# Altium schematic sheet tank_adapter.SchDoc
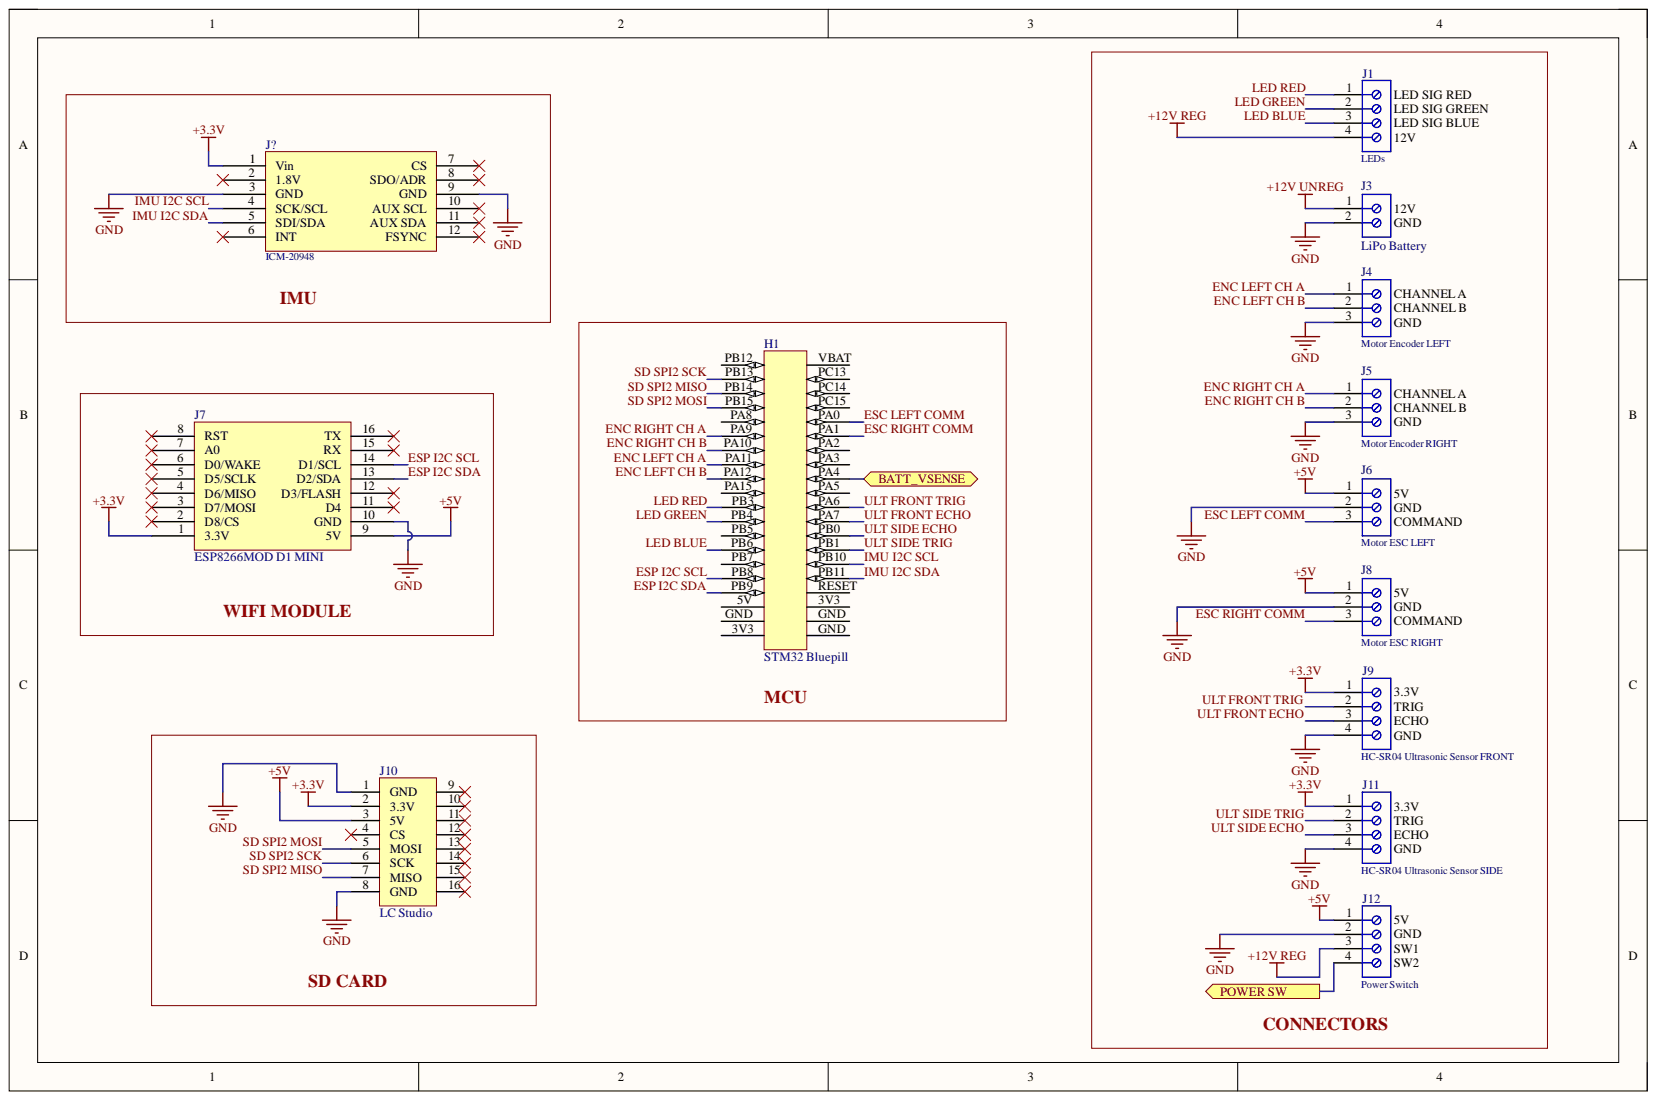
[diagram p0: tank_adapter.SchDoc]

## Parts
H1  STM32 Bluepill
J1  LEDs  [691709710304]
J3  LiPo Battery  [PHOE-1725656-2]
J4  Motor Encoder LEFT  [691213710003]
J5  Motor Encoder RIGHT  [691213710003]
J6  Motor ESC LEFT  [691213710003]
J7  ESP8266MOD D1 MINI
J8  Motor ESC RIGHT  [691213710003]
J9  HC-SR04 Ultrasonic Sensor FRONT  [691709710304]
J10  LC Studio  [HDR2X8_CEN]
J11  HC-SR04 Ultrasonic Sensor SIDE  [691709710304]
J12  Power Switch  [691709710304]
J?  ICM-20948  [61300611121]

## Ports
BATT_VSENSE, POWER SW

## Nets
+3.3V: J7.1(3.3V) J9.1 J10.2(3.3V) J11.1 J?.1(Vin)
+5V: J6.1 J7.9(5V) J8.1 J10.3(5V) J12.1
+12V REG: J1.4 J12.3
+12V UNREG: J3.1
GND: J3.2 J4.3 J5.3 J6.2 J7.10(GND) J8.2 J9.4 J10.1(GND) J10.8(GND) J11.4 J12.2 J?.3(GND) J?.9(GND)
BATT_VSENSE: H1.PA4 ; port BATT_VSENSE
ENC LEFT CH A: H1.PA11 J4.1
ENC LEFT CH B: H1.PA12 J4.2
ENC RIGHT CH A: H1.PA9 J5.1
ENC RIGHT CH B: H1.PA10 J5.2
ESC LEFT COMM: H1.PA0 J6.3
ESC RIGHT COMM: H1.PA1 J8.3
ESP I2C SCL: H1.PB8 J7.14(D1/SCL)
ESP I2C SDA: H1.PB9 J7.13(D2/SDA)
IMU I2C SCL: H1.PB10 J?.4(SCK/SCL)
IMU I2C SDA: H1.PB11 J?.5(SDI/SDA)
LED BLUE: H1.PB6 J1.3
LED GREEN: H1.PB4 J1.2
LED RED: H1.PB3 J1.1
POWER SW: J12.4 ; port POWER SW
SD SPI2 MISO: H1.PB14 J10.7(MISO)
SD SPI2 MOSI: H1.PB15 J10.5(MOSI)
SD SPI2 SCK: H1.PB13 J10.6(SCK)
ULT FRONT ECHO: H1.PA7 J9.3
ULT FRONT TRIG: H1.PA6 J9.2
ULT SIDE ECHO: H1.PB0 J11.3
ULT SIDE TRIG: H1.PB1 J11.2

Not connected: H1 3V3 5V GND PA2 PA3 PA5 PA8 PA15 PB5 PB7 PB12 PC13 PC14 PC15 RESET VBAT; J7 2(D8/CS) 3(D7/MOSI) 4(D6/MISO) 5(D5/SCLK) 6(D0/WAKE) 7(A0) 8(RST) 11(D4) 12(D3/FLASH) 15(RX) 16(TX); J10 4(CS) 9 10 11 12 13 14 15 16; J? 2(1.8V) 6(INT) 7(CS) 8(SDO/ADR) 10(AUX_SCL) 11(AUX_SDA) 12(FSYNC)

## Library data of the parts
J1 J9 J11 J12 = 4 Pin Terminal Block: Serie 7097 - 5.00 mm Horizontal Entry SMT with Pressure Clamp WR-TBL, 4 pin; Manufacturer: Wurth Elektronik; Pin Number: 4; Pitch: 5.00mm; Component Kind: Standard; Datasheet URL: http://katalog.we-online.de/em/datasheet/6917097103xx.pdf; Library Name: MTE380_Lib.SchLib; Manufacturer URL: http://www.we-online.de; Mounting Technology: SMT; Operating Temperature: -45to130degC; Package Reference: 691709710304; Packaging: TapeandReelwithCap; Part Number: 691709710304; Publisher: WurthElektronik
J3 = 2 Pin Terminal Block: Male Header, Pitch 2.54 mm, 1 x 2 Position, Height 9 mm, Tail Length 3.5 mm; Manufacturer: Phoenix Contact; Manufacturer part No.: 1725656; Content: 250pc(s); Industrial packaging: Yes; Number of contacts: 2; Pitch: 2.54mm; Colour: Green; Component Kind: Standard; Datasheet URL: http://datasheet.octopart.com/1725656-Phoenix-Contact-datasheet-5302866.pdf; Datasheet Version: Apr-08; Library Name: MTE380_Lib.SchLib; Manufacturer URL: http://www.phoenixcontact.com/; Type: MPT0,5/2-2,54
J4-J6 J8 = 3 Pin Terminal Block: Serie 213 - 5.00 mm Horizontal Entry Modular with RisingCage Clamp WR-TBL, 3 pin; Manufacturer: Wurth Elektronik; Pin Number: 3; Pitch: 5.00mm; Component Kind: Standard; Datasheet URL: http://katalog.we-online.de/em/datasheet/6912137100xx.pdf; Library Name: MTE380_Lib.SchLib; Manufacturer URL: http://www.we-online.de; Mounting Technology: THT; Operating Temperature: -40to105degC; Package Reference: 691213710003; Packaging: Box; Part Number: 691213710003; Publisher: WurthElektronik
J10 = Header 8X2A: Header, 8-Pin, Dual row; Component Kind: Standard; LatestRevisionDate: 17-Jul-2002; LatestRevisionNote: Re-released for DXP Platform.; Library Name: Miscellaneous Connectors.IntLib; Signal Integrity: Connector; Publisher: Altium Limited
J? = ICM-20948 2x6 Pin Header: THT Vertical Pin Header WR-PHD, Pitch 2.54 mm, Double Row, 2x6 pins; Manufacturer: Wurth Elektronik; Contact Resistance: 20mOhm; Gender: Male; Pin Number: 6; Pitch: 2.54mm; Rated Current: 3A; Row Number: Single; Withstanding Voltage: 500VAC; Working Voltage: 250VAC; Component Kind: Standard; Datasheet URL: https://katalog.we-online.de/em/datasheet/6130xx11121.pdf; Library Name: MTE380_Lib.SchLib; Manufacturer URL: http://www.we-online.de; Mounting Technology: THT; Operating Temperature: -40to105degC; Orientation: Vertical; Package Reference: 61300611121; Packaging: Bag; Part Number: 61300611121; Publisher: WurthElektronik
H1 = STM32 Bluepill: Component Kind: Standard; Library Name: Cell_Tester.SchLib
J7 = ESP8266MOD D1 MINI: Component Kind: Standard; Library Name: MTE380_Lib.SchLib
